# Altium schematic sheet impee-hannah.SchDoc
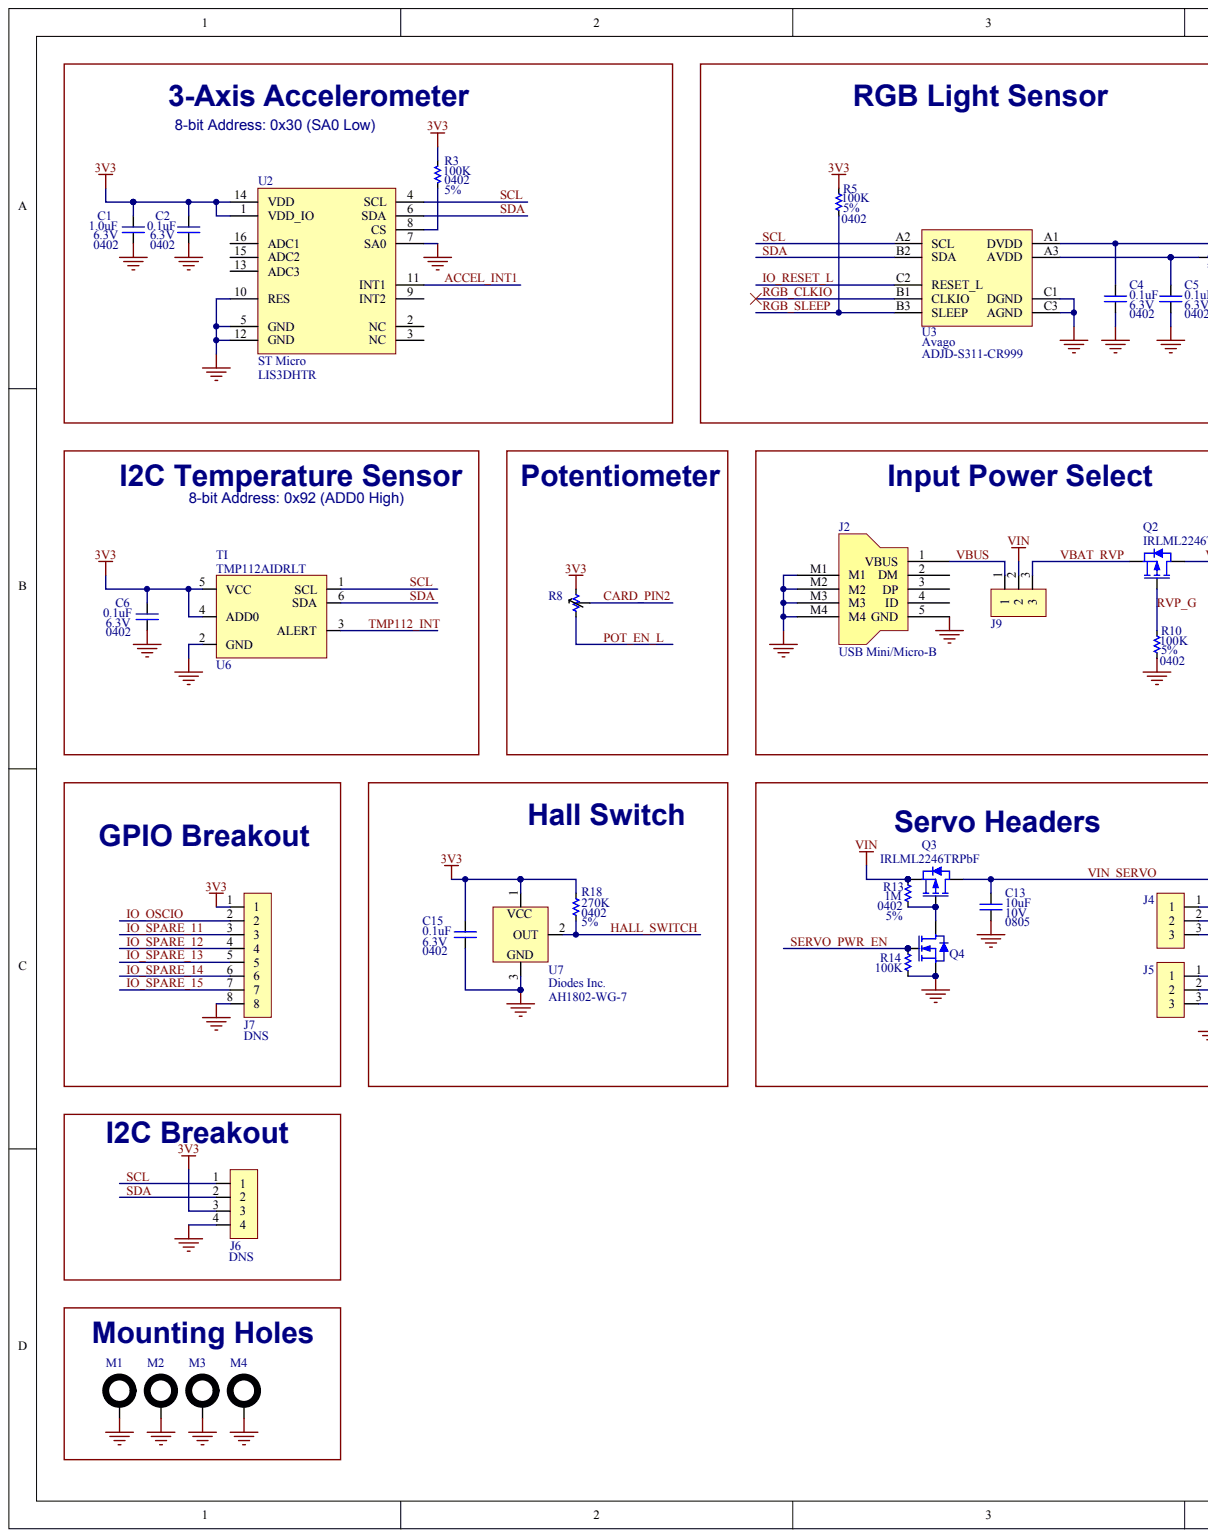
[diagram p0: impee-hannah.SchDoc, left]
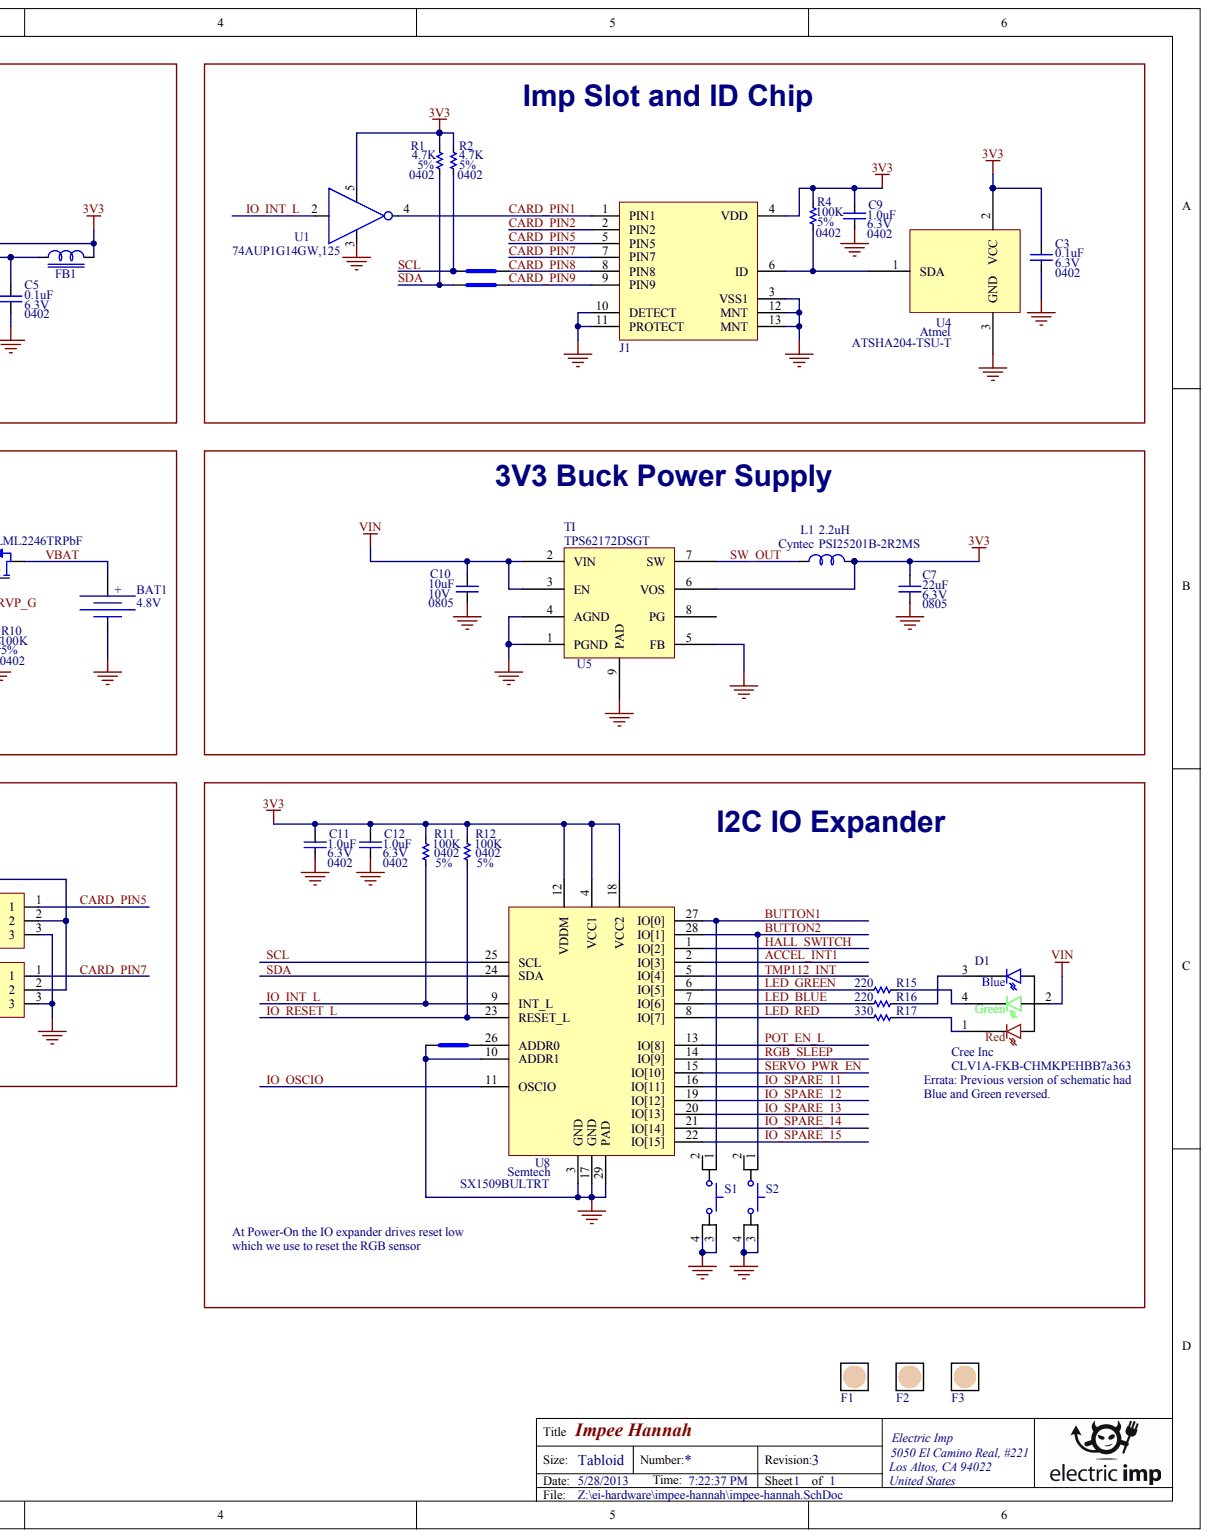
[diagram p1: impee-hannah.SchDoc, right]

## Parts
BAT1  Voltage (Nominal): 4.8V  (hidden comment: BATTERY)
C1  value 1.0uF  Voltage: 6.3V  Package: 0402  (hidden comment: Ceramic Capacitor)
C2  value 0.1uF  Voltage: 6.3V  Package: 0402  (hidden comment: Ceramic Capacitor)
C3  value 0.1uF  Voltage: 6.3V  Package: 0402  (hidden comment: Ceramic Capacitor)
C4  value 0.1uF  Voltage: 6.3V  Package: 0402  (hidden comment: Ceramic Capacitor)
C5  value 0.1uF  Voltage: 6.3V  Package: 0402  (hidden comment: Ceramic Capacitor)
C6  value 0.1uF  Voltage: 6.3V  Package: 0402  (hidden comment: Ceramic Capacitor)
C7  value 22uF  Voltage: 6.3V  Package: 0805  (hidden comment: Ceramic Capacitor)
C9  value 1.0uF  Voltage: 6.3V  Package: 0402  (hidden comment: Ceramic Capacitor)
C10  value 10uF  Voltage: 10V  Package: 0805  (hidden comment: Ceramic Capacitor)
C11  value 1.0uF  Voltage: 6.3V  Package: 0402  (hidden comment: Ceramic Capacitor)
C12  value 1.0uF  Voltage: 6.3V  Package: 0402  (hidden comment: Ceramic Capacitor)
C13  value 10uF  Voltage: 10V  Package: 0805  (hidden comment: Ceramic Capacitor)
C15  value 0.1uF  Voltage: 6.3V  Package: 0402  (hidden comment: Ceramic Capacitor)
D1  Manufacturer: Cree Inc  Manufacturer Part Number: CLV1A-FKB-CHMKPEHBB7a363  (hidden comment: RGB LED)
F1  Fiducial  (value not drawn)
F2  Fiducial  (value not drawn)
F3  Fiducial  (value not drawn)
FB1  Ferrite Bead  (value not drawn)
J1  Imp Slot  (value not drawn)
J2  USB Mini/Micro-B
J4  Header  (value not drawn)
J5  Header  (value not drawn)
J6  BOMOPTION: DNS  (hidden comment: Header)
J7  BOMOPTION: DNS  (hidden comment: Header)
J9  Header  (value not drawn)
L1  value 2.2uH  Manufacturer: Cyntec  Manufacturer Part Number: PSI25201B-2R2MS  (hidden comment: Inductor)
M1  Mounting Hole
M2  Mounting Hole
M3  Mounting Hole
M4  Mounting Hole
Q2  Manufacturer Part Number: IRLML2246TRPbF  (hidden comment: PFET)
Q3  Manufacturer Part Number: IRLML2246TRPbF  (hidden comment: PFET)
Q4  N-Channel MOSFET  (value not drawn)
R1  value 4.7K  Package: 0402  Tolerance: 5%  (hidden comment: Resistor)
R2  value 4.7K  Package: 0402  Tolerance: 5%  (hidden comment: Resistor)
R3  value 100K  Package: 0402  Tolerance: 5%  (hidden comment: Resistor)
R4  value 100K  Package: 0402  Tolerance: 5%  (hidden comment: Resistor)
R5  value 100K  Package: 0402  Tolerance: 5%  (hidden comment: Resistor)
R8  Potentiometer
R10  value 100K  Package: 0402  Tolerance: 5%  (hidden comment: Resistor)
R11  value 100K  Package: 0402  Tolerance: 5%  (hidden comment: Resistor)
R12  value 100K  Package: 0402  Tolerance: 5%  (hidden comment: Resistor)
R13  value 1M  Package: 0402  Tolerance: 5%  (hidden comment: Resistor)
R14  value 100K  (hidden comment: Resistor)
R15  value 220  (hidden comment: Resistor)
R16  value 220  (hidden comment: Resistor)
R17  value 330  (hidden comment: Resistor)
R18  value 270K  Package: 0402  Tolerance: 5%  (hidden comment: Resistor)
S1  Push Button  (value not drawn)
S2  Push Button  (value not drawn)
U1  Manufacturer Part Number: 74AUP1G14GW,125  (hidden comment: Inverter)
U2  LIS3DHTR  Manufacturer: ST Micro
U3  Manufacturer: Avago  Manufacturer Part Number: ADJD-S311-CR999  (hidden comment: ADJD-SC311-CR999)
U4  ATSHA204-TSU-T  Manufacturer: Atmel
U5  TPS62172  Supplier Part Number 1: TPS62172DSGT  Manufacturer: TI
U6  TMP112  Manufacturer: TI  Manufacturer Part Number: TMP112AIDRLT
U7  Manufacturer: Diodes Inc.  Manufacturer Part Number: AH1802-WG-7  (hidden comment: Hall Effect IC)
U8  Manufacturer: Semtech  Manufacturer Part Number: SX1509BULTRT  (hidden comment: I2C GPIO Expander)
XW2  XW Link  (value not drawn)
XW3  XW Link  (value not drawn)
XW4  XW Link  (value not drawn)

## Nets
3V3: C1.2 C2.2 C3.2 C4.2 C6.2 C7.2 C9.2 C11.2 C12.2 C15.2 FB1.2 J1.4(VDD) J6.3 J7.1 L1.2 R1.2 R2.2 R3.2 R4.2 R5.2 R8.3 R11.2 R12.2 R18.2 U1.5 U2.1(VDD_IO) U2.14(VDD) U3.A1(DVDD) U4.2 U5.6(VOS) U6.4(ADD0) U6.5(VCC) U7.1 U8.4(VCC1) U8.12(VDDM) U8.18(VCC2)
GND: BAT1.- C1.1 C2.1 C3.1 C4.1 C5.1 C6.1 C7.1 C9.1 C10.2 C11.1 C12.1 C13.2 C15.1 J1.3(VSS1) J1.10(DETECT) J1.11(PROTECT) J1.12(MNT) J1.13(MNT) J2.5(GND) J2.M1 J2.M2 J2.M3 J2.M4 J4.3 J5.3 J6.4 J7.8 M1.1 M2.1 M3.1 M4.1 Q4.2 R10.1 R14.1 S1.3 S1.4 S2.3 S2.4 U1.3 U2.5(GND) U2.7(SA0) U2.10(RES) U2.12(GND) U3.C1(DGND) U3.C3(AGND) U4.3 U5.1(PGND) U5.4(AGND) U5.5(FB) U5.9(PAD) U6.2(GND) U7.3 U8.3(GND) U8.10(ADDR1) U8.17(GND) U8.29(PAD) XW4.2
VIN: C10.1 D1.2 J9.2 Q3.2 R13.2 U5.2(VIN) U5.3(EN)
ACCEL_INT1: U2.11(INT1) U8.2(IO[3])
BUTTON1: S1.1 U8.27(IO[0])
BUTTON2: S2.1 U8.28(IO[1])
CARD_PIN1: J1.1(PIN1) U1.4
CARD_PIN2: J1.2(PIN2) R8.2
CARD_PIN5: J1.5(PIN5) J4.1
CARD_PIN7: J1.7(PIN7) J5.1
CARD_PIN8: J1.8(PIN8) XW2.1
CARD_PIN9: J1.9(PIN9) XW3.1
HALL_SWITCH: R18.1 U7.2 U8.1(IO[2])
IO_INT_L: R11.1 U1.2 U8.9(INT_L)
IO_OSCIO: J7.2 U8.11(OSCIO)
IO_RESET_L: R12.1 U3.C2(RESET_L) U8.23(RESET_L)
IO_SPARE_11: J7.3 U8.16(IO[11])
IO_SPARE_12: J7.4 U8.19(IO[12])
IO_SPARE_13: J7.5 U8.20(IO[13])
IO_SPARE_14: J7.6 U8.21(IO[14])
IO_SPARE_15: J7.7 U8.22(IO[15])
LED_BLUE: R16.1 U8.7(IO[6])
LED_GREEN: R15.1 U8.6(IO[5])
LED_RED: R17.1 U8.8(IO[7])
POT_EN_L: R8.1 U8.13(IO[8])
RGB_CLKIO: U3.B1(CLKIO)
RGB_SLEEP: R5.1 U3.B3(SLEEP) U8.14(IO[9])
RVP_G: Q2.1 R10.2
SCL: J6.1 R2.1 U2.4(SCL) U3.A2(SCL) U6.1(SCL) U8.25(SCL) XW2.2
SDA: J6.2 R1.1 U2.6(SDA) U3.B2(SDA) U6.6(SDA) U8.24(SDA) XW3.2
SERVO_PWR_EN: Q4.1 R14.2 U8.15(IO[10])
SW_OUT: L1.1 U5.7(SW)
TMP112_INT: U6.3(ALERT) U8.5(IO[4])
VBAT: BAT1.+ Q2.3
VBAT_RVP: J9.3 Q2.2
VBUS: J2.1(VBUS) J9.1
VIN_SERVO: C13.1 J4.2 J5.2 Q3.3
NetC5_2: C5.2 FB1.1 U3.A3(AVDD)
NetD1_1: D1.1 R17.2
NetD1_3: D1.3 R16.2
NetD1_4: D1.4 R15.2
NetJ1_6: J1.6(ID) R4.1 U4.1
NetQ3_1: Q3.1 Q4.3 R13.1
NetR3_1: R3.1 U2.8(CS)
NetU8_26: U8.26(ADDR0) XW4.1

Not connected: J2 2(DM) 3(DP) 4(ID); S1 2; S2 2; U2 2(NC) 3(NC) 9(INT2) 13(ADC3) 15(ADC2) 16(ADC1); U5 8(PG)

## Library data of the parts
BAT1 = (no symbol name): Manufacturer Part Number: 12BH349-GR; Manufacturer: Eagle Plastic Devices; Part Number: BAT00001; Voltage (Min): 3.6V; Voltage (Max): 6.8V; Supplier 1: Mouser; Supplier Part Number 1: 12BH349-GR; Supplier Price 1: 0.453
C1 C9 C11 C12 = (no symbol name): Part Number: CAPC00005; Manufacturer: Taiyo Yuden; Manufacturer Part Number: JMK105BJ105KV-F; Tolerance: 10%; Dielectric: X5R; Supplier 1: Digi-Key; Supplier Part Number 1: 587-1231-1-ND; Supplier Price 1: 0.009; Manufacturer 2: Yageo; Manufacturer Part Number 2: CC0402KRX5R5BB105; Supplier 2: Digi-Key; Supplier Part Number 2: 311-1438-1-ND; Supplier Price 2: .00948
C2-C6 C15 = (no symbol name): Part Number: CAPC00002; Manufacturer: TDK; Manufacturer Part Number: C1005X5R0J104K; Tolerance: 10%; Dielectric: X5R; Manufacturer 2: Murata; Manufacturer Part Number 2: GRM155R60J104KA01D; Supplier 1: Digi-Key; Supplier Part Number 1: 445-1266-1-ND; Supplier Price 1: 0.0066; Supplier 2: Mouser; Supplier Part Number 2: 81-GRM155R60J104KA1D; Supplier Price 2: 0.007
C7 = (no symbol name): Part Number: CAPC00039; Manufacturer: TDK; Manufacturer Part Number: C2012X5R0J226K/1.25; Tolerance: 10%; Dielectric: X5R; Manufacturer 2: Taiyo Yuden; Manufacturer Part Number 2: JMK325BJ226KM-T; Supplier 1: Digi-Key; Supplier Part Number 1: 445-7679-1-ND; Supplier Price 1: 0.225; Supplier 2: Digi-Key; Supplier Part Number 2: 587-1471-2-ND; Supplier Price 2: 0.22880
C10 C13 = (no symbol name): Part Number: CAPC00003; Manufacturer: TDK; Manufacturer Part Number: C2012X5R1A106KT/1.25; Tolerance: 10%; Dielectric: X5R; Manufacturer 2: Murata; Manufacturer Part Number 2: GRM21BR61A106KE19L; Supplier 1: Mouser; Supplier Part Number 1: 810-C2012X5R1A106K-3; Supplier Price 1: 0.039; Supplier 2: Mouser; Supplier Part Number 2: 81-GRM21BR61A106KE19; Supplier Price 2: 0.045
D1 = (no symbol name): Part Number: LED00001; Millicandela Rating: 355 mcd, 560 mcd, 180 mcd; Voltage - Forward (Vf) Typ: 2V Red, 3.2V Green, 3.2V Blue; Current - Test: 20mA; Wavelength - Dominant: 630 nm, 527 nm, 470 nm; Wavelength - Peak: 630nm, 527nm, 470nm; Viewing Angle: 120°; Lens Type: Diffused, White; Color: RGB; Package: PLCC-4; Supplier 1: Mouser; Supplier Part Number 1: 941-V1AFKBCHMKPEH7A3; Supplier Price 1: 0.289; ComponentLink1URL: http://www.cree.com/products/pdf/LEDlamps/CLV1A-FKB(874).pdf
F1-F3 = (no symbol name): Part Number: FID00001
FB1 = (no symbol name): Part Number: FB00001; Manufacturer: TDK; Manufacturer Part Number: MMZ1005S601C; Impedance @ Frequency: 600 Ohm @ 100Mhz; Current Rating: 300mA; DC Resistance (DCR): 600 mOhm; Package: 0402; Supplier 1: Digikey; Supplier Part Number 1: 445-2162-1-ND; Supplier Price 1: 0.03; Supplier 2: Mouser; Supplier Part Number 2: 810-MMZ1005S601C; Supplier Price 2: .031; ComponentLink1URL: http://www.tdk.co.jp/tefe02/e9412_mmz.pdf
J1 = (no symbol name): Part Number: IMP_SLOT00001; Manufacturer: Proconn; Manufacturer Part Number: SDC009-A0-2274; Manufacturer 2: 4UCON; Manufacturer Part Number 2: 19646; Supplier 1: Proconn; Supplier Part Number 1: SDC0009; Supplier Price 1: 0.45
J2 = (no symbol name): Part Number: USB00001; Manufacturer: 4UCON; Manufacturer Part Number: 20035; Supplier 1: 4UCON; Supplier Part Number 1: 20035; Supplier Price 1: 0.0925
J4 J5 J9 = (no symbol name): Part Number: HDR00003; Manufacturer: 4UCON; Manufacturer Part Number: 00798; Gender: Male; Connector Type: Unshrouded; Number of Columns: 3; Pitch: 2.54mm; Number of Rows: 1; Number of Positions Loaded: 3; Mounting Type: Through Hole; Orientation: Vertical; Contact Finish: Gold Flash; Supplier 1: 4UCON; Supplier Part Number 1: 00798; Supplier Price 1: 0.0069
J6 = (no symbol name): Part Number: HDR00004; Manufacturer: 4UCON; Manufacturer Part Number: 00804; Gender: Male; Connector Type: Unshrouded; Number of Columns: 4; Pitch: 2.54mm; Number of Rows: 1; Number of Positions Loaded: 4; Mounting Type: Through Hole; Orientation: Vertical; Contact Finish: Gold Flash; Supplier 1: 4UCON; Supplier Part Number 1: 00804; Supplier Price 1: 0.0093
J7 = (no symbol name): Part Number: HDR00008; Manufacturer: 4UCON; Manufacturer Part Number: 00811; Gender: Male; Connector Type: Unshrouded; Number of Columns: 8; Pitch: 2.54mm; Number of Rows: 1; Number of Positions Loaded: 8; Mounting Type: Through Hole; Orientation: Vertical; Contact Finish: Gold Flash; Supplier 1: 4UCON; Supplier Part Number 1: 00811; Supplier Price 1: 0.0183
L1 = (no symbol name): Part Number: IND00001; Current: 1.3A; Current - Saturation: 2.0A; Tolerance: 20%; DC Resistance (DCR): 155 mOhm; Package: 2520; Current - Temperature Rise: 1.3A; Supplier 1: Cyntec; Supplier Part Number 1: PSI25201B-2R2MS; Supplier Price 1: 0.05; ComponentLink1URL: http://www.cyntec.com/product/sealed_choke/download/PSI25201B.pdf
Q2 Q3 = (no symbol name): Part Number: PFET00001; Manufacturer: International Rectifier; Drain to Source Voltage (Vdss): 20V; Current - Continuous Drain (Id) @ 25° C: 2.6A; Rds On (Max) @ Id, Vgs: 135 mOhm @ 2.6A, 4.5V; Vgs(th) (Max) @ Id: 1.1V @ 10µA; Power - Max: 1.3W; Supplier 1: Mouser; Supplier Part Number 1: 942-IRLML2246TRPBF; Supplier Price 1: 0.119
Q4 = (no symbol name): Part Number: NFET00001; Manufacturer: NXP; Manufacturer Part Number: 2N7002PW,115; Drain to Source Voltage (Vdss): 60V; Current - Continuous Drain (Id) @ 25° C: 310mA; Rds On (Max) @ Id, Vgs: 1.6 Ohm @ 500mA, 10V; Vgs(th) (Max) @ Id: 2.4V @ 250µA; Power - Max: 260mW; Supplier 1: Mouser; Supplier Part Number 1: 771-2N7002PW-115; Package: SOT323; Supplier Price 1: 0.041; ComponentLink1URL: http://www.nxp.com/documents/data_sheet/2N7002PW.pdf
R1 R2 = (no symbol name): Part Number: RES00005; Manufacturer: Yageo; Manufacturer Part Number: RC0402JR-074K7L; Power: 0.063W; Manufacturer 2: ROHM; Manufacturer Part Number 2: MCR01MRTJ472; Supplier 1: Digi-Key; Supplier 2: Digi-Key; Supplier Part Number 1: 311-4.7KJRCT-ND; Supplier Part Number 2: RHM4.7KCECT-ND; Supplier Price 1: 0.00237; Supplier Price 2: 0.00352
R3-R5 R10-R12 = (no symbol name): Part Number: RES00001; Manufacturer: Stackpole; Manufacturer Part Number: RMCF0402JT100K; Power: 0.063W; Manufacturer 2: ROHM; Manufacturer Part Number 2: MCR01MRTJ104; Supplier 1: Digi-Key; Supplier Part Number 1: RMCF0402JT100KCT-ND; Supplier Price 1: 0.00256; Supplier 2: Digi-Key; Supplier Part Number 2: RHM100KCECT-ND; Supplier Price 2: 0.00352
R8 = (no symbol name): Part Number: POT00001; Manufacturer: Panasonic; Manufacturer Part Number: EVU-F2AF30B14; Scale: Linear; Value: 10k; Tolerance: 20%; Power: 0.063W; Adjustment Type: Top Adjustment; Actuator Length: 0.909" (23.10mm); Actuator Type: Flatted Shaft; Actuator Diameter: 0.236" (6.00mm); Supplier 1: Digi-key; Supplier Part Number 1: P3C3103-ND; Supplier Price 1: 0.46978; ComponentLink1URL: http://www.panasonic.com/industrial/components/pdf/aok0000ce4.pdf
R13 = (no symbol name): Part Number: RES00010; Manufacturer: Yageo; Manufacturer Part Number: RC0402JR-071ML; Power: 0.063W; Manufacturer 2: ROHM; Manufacturer Part Number 2: MCR01MRTJ105; Supplier 1: Digi-Key; Supplier Part Number 1: 311-1.0MJRCT-ND; Supplier 2: Digi-Key; Supplier Part Number 2: RHM1.0MCECT-ND; Supplier Price 1: 0.00237; Supplier Price 2: 0.00352
R14 = (no symbol name): Part Number: RES00001; Manufacturer: Stackpole; Manufacturer Part Number: RMCF0402JT100K; Tolerance: 5%; Power: 0.063W; Package: 0402; Manufacturer 2: ROHM; Manufacturer Part Number 2: MCR01MRTJ104; Supplier 1: Digi-Key; Supplier Part Number 1: RMCF0402JT100KCT-ND; Supplier Price 1: 0.00256; Supplier 2: Digi-Key; Supplier Part Number 2: RHM100KCECT-ND; Supplier Price 2: 0.00352
R15 R16 = (no symbol name): Part Number: RES00011; Manufacturer: Yageo; Manufacturer Part Number: RC0402JR-07220RL; Tolerance: 5%; Power: 0.063W; Package: 0402; Manufacturer 2: Panasonic; Manufacturer Part Number 2: ERJ-2GEJ221X; Supplier 1: Digi-Key; Supplier Part Number 1: 311-220JRCT-ND; Supplier 2: Digi-Key; Supplier Part Number 2: P220JCT-ND; Supplier Price 1: 0.00237; Supplier Price 2: 0.0048
R17 = (no symbol name): Part Number: RES00012; Manufacturer: Yageo; Manufacturer Part Number: RC0402JR-07330RL; Tolerance: 5%; Power: 0.063W; Package: 0402; Manufacturer 2: Panasonic; Manufacturer Part Number 2: ERJ-2GEJ331X; Supplier 1: Digi-Key; Supplier Part Number 1: 311-330JRCT-ND; Supplier 2: Digi-Key; Supplier Part Number 2: P330JCT-ND; Supplier Price 1: 0.00237; Supplier Price 2: 0.0048
R18 = (no symbol name): Part Number: RES00015; Manufacturer: Yageo; Manufacturer Part Number: RC0402JR-07270KL; Power: 0.063W; Manufacturer 2: ROHM; Manufacturer Part Number 2: MCR01MZPJ274; Supplier 1: Digi-Key; Supplier Part Number 1: 311-270KJRDKR-ND; Supplier Price 1: 0.00237; Supplier 2: Digi-Key; Supplier Part Number 2: RHM270KJCT-ND; Supplier Price 2: 0.00384
S1 S2 = (no symbol name): Manufacturer: TE Connectivity; Manufacturer Part Number: FSM4JSMA; Part Number: BTN00001; Supplier 1: Digi-Key; Supplier Part Number 1: 450-1129-ND; Supplier Price 1: 0.1179
U1 = (no symbol name): Manufacturer: NXP; Part Number: IC00001; Supplier Part Number 1: 771-AUP1G14GW125; Supplier 1: Mouser; Supplier Price 1: 0.148; ComponentLink1URL: http://www.nxp.com/documents/data_sheet/74AUP1G14.pdf
U2 = (no symbol name): Part Number: IC00038; Manufacturer Part Number: LIS3DHTR; Supplier 1: Digi-Key; Supplier Part Number 1: 497-10613-1-ND; Supplier Price 1: 1.09366; Supplier 2: Mouser; Supplier Part Number 2: 511-LIS3DHTR; Supplier Price 2: 1.06; ComponentLink1URL: http://www.st.com/internet/com/TECHNICAL_RESOURCES/TECHNICAL_LITERATURE/DATASHEET/CD00172345.pdf
U3 = (no symbol name): Part Number: IC00003; Supplier 1: Mouser; Supplier Part Number 1: 630-ADJD-S311-CR999; Supplier Price 1: 2.04; ComponentLink1URL: http://media.digikey.com/pdf/Data%20Sheets/Avago%20PDFs/ADJD-S311-CR999.pdf
U4 = (no symbol name): Part Number: IC00007; Supplier 1: Avnet; Supplier Part Number 1: ATSHA204-TSU-T; Manufacturer Part Number: ATSHA204-TSU-T; Supplier Price 1: 0.42; ComponentLink1URL: http://www.atmel.com/Images/doc8740.pdf
U5 = (no symbol name): Part Number: IC00004; Supplier 1: Avnet; Manufacturer Part Number: TPS62172DSGT; Supplier Price 1: 0.95; ComponentLink1URL: http://www.ti.com/lit/ds/symlink/tps62170.pdf; Supplier 2: Mouser; Supplier Part Number 2: 595-TPS62172DSGT; Supplier Price 2: 1.41
U6 = (no symbol name): Part Number: IC00024; Supplier 1: Mouser; Supplier Part Number 1: 595-TMP112AIDRLT; Supplier Price 1: 1.28; ComponentLink1URL: http://www.ti.com/lit/ds/symlink/tmp112.pdf
U7 = (no symbol name): Part Number: IC00014; Supplier 1: Mouser; Supplier Part Number 1: 621-AH1802-WG-7; Supplier Price 1: 0.31; ComponentLink1URL: http://www.mouser.com/search/ProductDetail.aspx?qs=oUsD4qhOtFyHThs%252bhO3u5A%3d%3d
U8 = (no symbol name): Part Number: IC00006; Supplier 1: Digi-Key; Supplier Part Number 1: SX1509BULTRT; Supplier Price 1: 1.12; ComponentLink1URL: http://www.semtech.com/images/datasheet/sx150x_789.pdf
